# Altium schematic sheet sign_controller.SchDoc
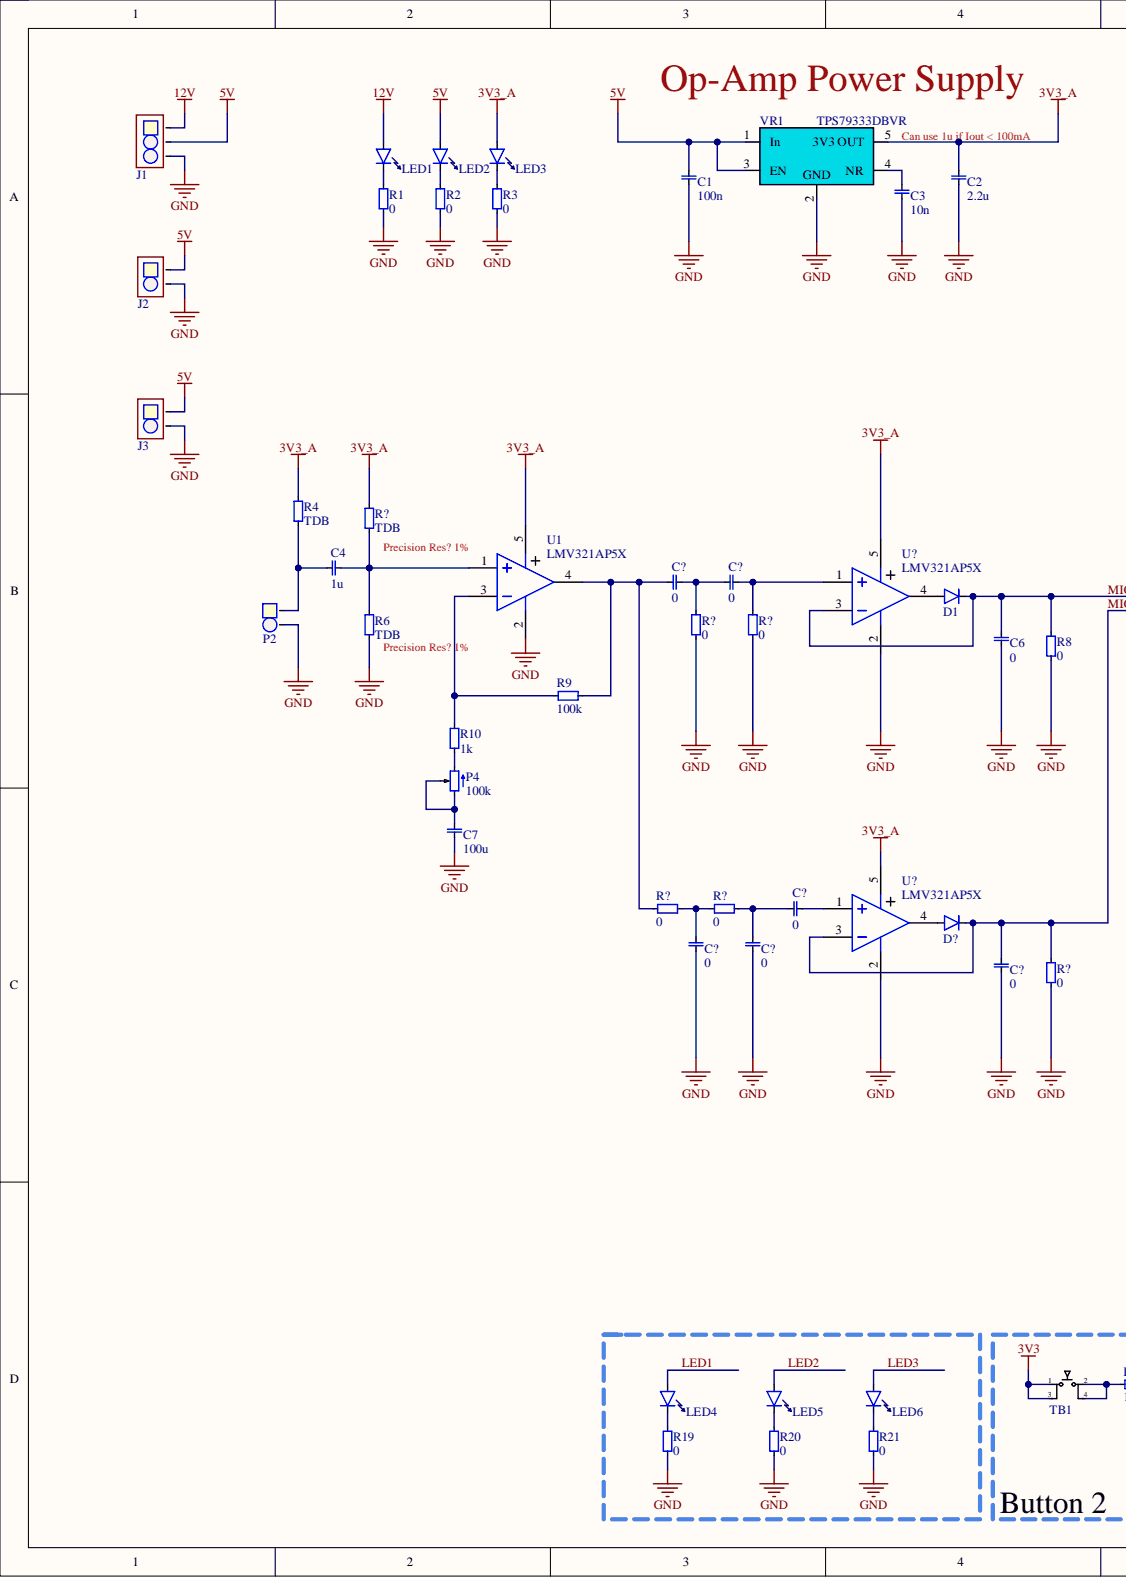
[diagram p0: sign_controller.SchDoc, left]
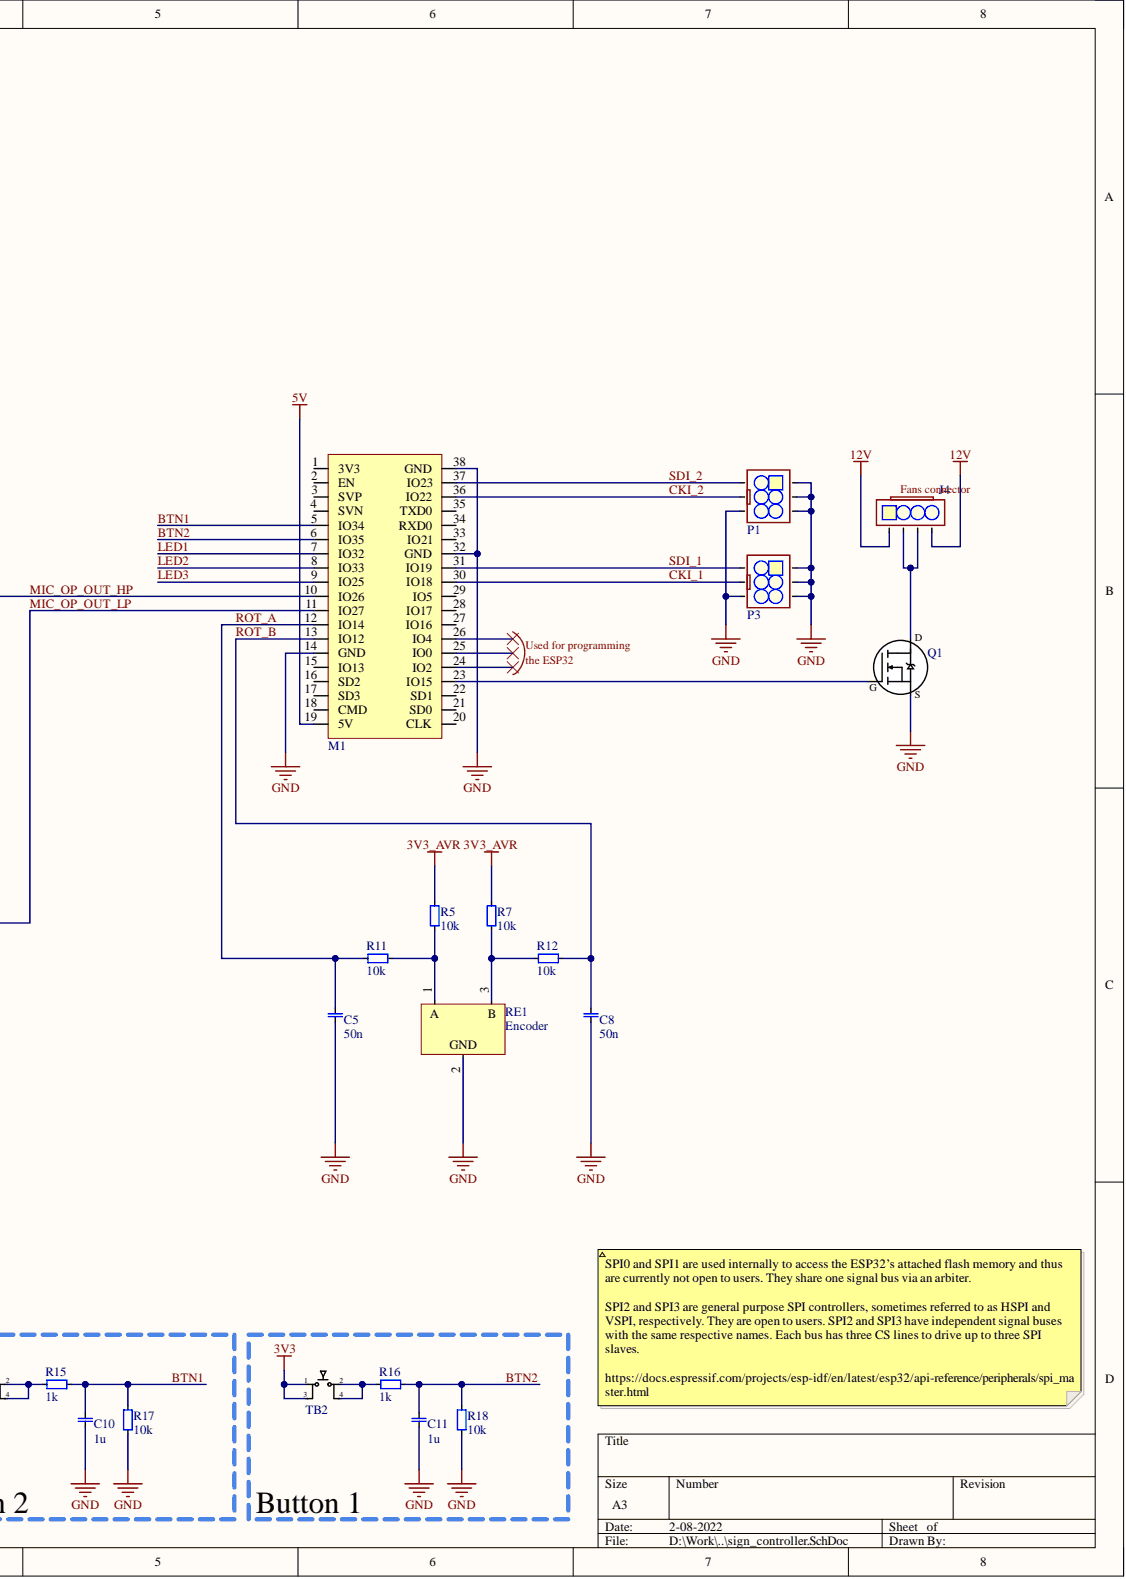
[diagram p1: sign_controller.SchDoc, right]

## Parts
C1  value 100n  [0603 cap]  (hidden comment: 0603, capacitor)
C2  value 2.2u  [1206, capasitor]  (hidden comment: 1206, capacitor)
C3  value 10n  [0603 cap]  (hidden comment: 0603, capacitor)
C4  value 1u  [1206, capasitor]  (hidden comment: 1206, capacitor)
C5  value 50n  [0603 cap]  (hidden comment: 0603, capacitor)
C6  value 0  [0603 cap]  (hidden comment: 0603, capacitor)
C7  value 100u  [1206, capasitor]  (hidden comment: 1206, capacitor)
C8  value 50n  [0603 cap]  (hidden comment: 0603, capacitor)
C10  value 1u  [0603 cap]  (hidden comment: 0603, capacitor)
C11  value 1u  [0603 cap]  (hidden comment: 0603, capacitor)
C?  value 0  [0603 cap]  (hidden comment: 0603, capacitor)
C?  value 0  [0603 cap]  (hidden comment: 0603, capacitor)
C?  value 0  [0603 cap]  (hidden comment: 0603, capacitor)
C?  value 0  [0603 cap]  (hidden comment: 0603, capacitor)
C?  value 0  [0603 cap]  (hidden comment: 0603, capacitor)
C?  value 0  [0603 cap]  (hidden comment: 0603, capacitor)
D1  TH, diode  [TH]  (value not drawn)
D?  TH, diode  [TH]  (value not drawn)
J1  3 Pole MSTBA Connector  [3POLE_MSTBA_ANGLE]  (value not drawn)
J2  2 Pole MSTBA Connector  [2POLE_MSTBA_ANGLE]  (value not drawn)
J3  2 Pole MSTBA Connector  [2POLE_MSTBA_ANGLE]  (value not drawn)
J4  1x4 Molex  [1x4 Bent Pin Header]  (value not drawn)
LED1  0805, LED  [0805_led]  (value not drawn)
LED2  0805, LED  [0805_led]  (value not drawn)
LED3  0805, LED  [0805_led]  (value not drawn)
LED4  0805, LED  [0805_led]  (value not drawn)
LED5  0805, LED  [0805_led]  (value not drawn)
LED6  0805, LED  [0805_led]  (value not drawn)
M1  ESP32-DEVKITC-32D-F  [ESP32_DEVKITC]  (value not drawn)
P1  2x3 Pin Header  [2x3 PH]  (value not drawn)
P2  1x2 Pin Header  [padtwo_L]  (value not drawn)
P3  2x3 Pin Header  [2x3 PH]  (value not drawn)
P4  value 100k  [POT_TH1]  (hidden comment: Pot)
Q1  IRLB8314  [TO-220]  (value not drawn)
R1  value 0  [0603]  (hidden comment: 0603, resistor)
R2  value 0  [0603]  (hidden comment: 0603, resistor)
R3  value 0  [0603]  (hidden comment: 0603, resistor)
R4  value TDB  [0603]  (hidden comment: 0603, resistor)
R5  value 10k  [0603]  (hidden comment: 0603, resistor)
R6  value TDB  [0603]  (hidden comment: 0603, resistor)
R7  value 10k  [0603]  (hidden comment: 0603, resistor)
R8  value 0  [0603]  (hidden comment: 0603, resistor)
R9  value 100k  [0603]  (hidden comment: 0603, resistor)
R10  value 1k  [0603]  (hidden comment: 0603, resistor)
R11  value 10k  [0603]  (hidden comment: 0603, resistor)
R12  value 10k  [0603]  (hidden comment: 0603, resistor)
R15  value 1k  [0402 res]  (hidden comment: 0603, resistor)
R16  value 1k  [0402 res]  (hidden comment: 0603, resistor)
R17  value 10k  [0402 res]  (hidden comment: 0603, resistor)
R18  value 10k  [0402 res]  (hidden comment: 0603, resistor)
R19  value 0  [0603]  (hidden comment: 0603, resistor)
R20  value 0  [0603]  (hidden comment: 0603, resistor)
R21  value 0  [0603]  (hidden comment: 0603, resistor)
R?  value TDB  [0603]  (hidden comment: 0603, resistor)
R?  value 0  [0603]  (hidden comment: 0603, resistor)
R?  value 0  [0603]  (hidden comment: 0603, resistor)
R?  value 0  [0603]  (hidden comment: 0603, resistor)
R?  value 0  [0603]  (hidden comment: 0603, resistor)
R?  value 0  [0603]  (hidden comment: 0603, resistor)
RE1  Encoder  [RotaryEncoder]
TB1  Tactile Button  [tacButton smd]  (value not drawn)
TB2  Tactile Button  [tacButton smd]  (value not drawn)
U1  LMV321AP5X  [SOT23-5]
U?  LMV321AP5X  [SOT23-5]
U?  LMV321AP5X  [SOT23-5]
VR1  TPS79333DBVR  [SOT23-5]

## Nets
3V3: TB1.1 TB1.3 TB2.1 TB2.3
3V3_A: C2.1 LED3.1 R4.0 R?.1 U1.5 U?.5 VR1.5(3V3_OUT)
3V3_AVR: R5.1 R7.1
5V: C1.1 J1.2 J2.1 J3.1 LED2.1 M1.19(5V) VR1.1(In) VR1.3(EN)
12V: J1.1 J4.1 J4.4 LED1.1
GND: C1.0 C2.0 C3.0 C5.0 C6.0 C7.0 C8.0 C10.0 C11.0 C?.0 C?.1 J1.3 J2.2 J3.2 M1.14(GND) M1.32(GND) M1.38(GND) P1.1 P1.2 P1.3 P1.4 P2.2 P3.1 P3.2 P3.3 P3.4 Q1.3 R1.1 R2.1 R3.1 R6.1 R8.1 R17.1 R18.0 R19.1 R20.1 R21.1 R?.1 RE1.2 U1.2 U?.2 VR1.2
BTN1: C10.1 M1.5(IO34) R15.1 R17.0
BTN2: C11.1 M1.6(IO35) R16.1 R18.1
CKI_1: M1.30(IO18) P3.5
CKI_2: M1.36(IO22) P1.5
LED1: LED4.1 M1.7(IO32)
LED2: LED5.1 M1.8(IO33)
LED3: LED6.1 M1.9(IO25)
MIC_OP_OUT_HP: C6.1 D1.2 M1.10(IO26) R8.0 U?.3
MIC_OP_OUT_LP: C?.1 D?.2 M1.11(IO27) R?.0 U?.3
ROT_A: C5.1 M1.12(IO14) R11.0
ROT_B: C8.1 M1.13(IO12) R12.0
SDI_1: M1.31(IO19) P3.6
SDI_2: M1.37(IO23) P1.6
NetC3_1: C3.1 VR1.4(NR)
NetC4_0: C4.0 R6.0 R?.0 U1.1
NetC4_1: C4.1 P2.1 R4.1
NetC7_1: C7.1 P4.1 P4.2
NetC?_0: C?.0 C?.1 R?.0 R?.1 U?.1
NetC?_1: C?.1 R9.1 R?.0 U1.4
NetD1_1: D1.1 U?.4
NetD?_1: D?.1 U?.4
NetJ4_2: J4.2 J4.3 Q1.2
NetLED1_0: LED1.0 R1.0
NetLED2_0: LED2.0 R2.0
NetLED3_0: LED3.0 R3.0
NetLED4_0: LED4.0 R19.0
NetLED5_0: LED5.0 R20.0
NetLED6_0: LED6.0 R21.0
NetM1_23: M1.23(IO15) Q1.1
NetP4_3: P4.3 R10.1
NetR5_0: R5.0 R11.1 RE1.1
NetR7_0: R7.0 R12.1 RE1.3
NetR9_0: R9.0 R10.0 U1.3
NetR15_0: R15.0 TB1.2 TB1.4
NetR16_0: R16.0 TB2.2 TB2.4

Not connected: M1 1(3V3) 2(EN) 3(SVP) 4(SVN) 15(IO13) 16(SD2) 17(SD3) 18(CMD) 20(CLK) 21(SD0) 22(SD1) 24(IO2) 25(IO0) 26(IO4) 27(IO16) 28(IO17) 29(IO5) 33(IO21) 34(RXD0) 35(TXD0)

## Library data of the parts
LED1-LED6 = 0805, LED: Value: 0805 LED; Component Kind: Standard; Library Name: sign_lib.IntLib
P1 P3 = 2x3 Ribbon connector: Value: 2x3 Pin Header, 2.54mm; Component Kind: Standard; Description: 2x3 Ribbon connector; Library Name: sign_lib.SchLib
P2 = 1x2 Pin Header: 2.54 mm, TH; Component Kind: Standard; Value: 1x2 Pin Header; Library Name: sign_lib.IntLib
RE1 = RotaryEncoder: Component Kind: Standard; Library Name: sign_lib.IntLib
TB1 TB2 = TacButton SMD: Tactile Switch Small Low; Component Kind: Standard; ELFA: 110-87-406; Value: Button SMD; Library Name: jclib.IntLib
U1 U? U? = LMV321AP5X: Operational Amplifier, Low Power, Rail to Rail Output, CMOS Op-Amp; Manufacturer: ON Semiconductor / Fairchild; Bandwidth: 1.4MHz; Common Mode Rejection Ratio: 50dB; Input Bias Current: 1nA; Input Offset Voltage (Vos): 7mV; Max Dual Supply Voltage: 2.75V; Max Supply Voltage: 5.5V; Min Dual Supply Voltage: 1.35V; Min Supply Voltage: 2.7V; Nominal Supply Current: 80uA; Number of Amplifiers: 1; Operating Supply Current: 100uA; Output Current: 34mA; Slew Rate: 1.5V/µs; Voltage Gain: 70dB; Case/Package: SC; Category: Amplifiers - Op Amps, Buffer, Instrumentation; Component Kind: Standard; ComponentLink1Description: https://datasheet.ciiva.com/25629/0900766b810c08e0-25629312.pdf?src-supplier=RSComponents; ComponentLink1URL: https://datasheet.ciiva.com/25629/0900766b810c08e0-25629312.pdf?src-supplier=RSComponents; ComponentLink2Description: https://datasheet.ciiva.com/17359/lmv321-17359885.pdf?src-supplier=Future+Electronics; ComponentLink2URL: https://datasheet.ciiva.com/17359/lmv321-17359885.pdf?src-supplier=Future+Electronics; ComponentLink3Description: https://datasheet.ciiva.com/26243/lmv358-26243564.pdf?src-supplier=Avnet+Europe; ComponentLink3URL: https://datasheet.ciiva.com/26243/lmv358-26243564.pdf?src-supplier=Avnet+Europe; ComponentLink4Description: https://datasheet.ciiva.com/1792/lmv321-1792987.pdf?src-supplier=Digi-Key; ComponentLink4URL: https://datasheet.ciiva.com/1792/lmv321-1792987.pdf?src-supplier=Digi-Key; ComponentLink5Description: https://datasheet.ciiva.com/1792/lmv321-1792988.pdf?src-supplier=Digi-Key; ComponentLink5URL: https://datasheet.ciiva.com/1792/lmv321-1792988.pdf?src-supplier=Digi-Key; ComponentLink6Description: https://datasheet.ciiva.com/1792/lmv321-1792986.pdf?src-supplier=Digi-Key; ComponentLink6URL: https://datasheet.ciiva.com/1792/lmv321-1792986.pdf?src-supplier=Digi-Key; Gain Bandwidth Product: 1.4MHz; Height: 1mm; Lead Free: Lead Free; Length: 2.2mm; Manufacturer Part Number: LMV321AP5X; Max Operating Temperature: 125°C; Min Operating Temperature: -40°C; Mount: Surface Mount; Number of Channels: 1; Number of Circuits: 1; Number of Elements: 1; Number of Pins: 5; Operating Supply Voltage: 5.5V; Output Current per Channel: 34mA; Package Quantity: 1; Packaging: Tape and Reel; Power Supply Rejection Ratio (PSRR): 50dB; Pricing 1: 1=0,51000, 10=0,43100, 50=0,43100, 100=0,29900, 500=0,25300, 1000=0,19600, 3000=0,17700, 9000=0,16700, 10000=0,16700 (USD); Radiation Hardening: No; REACH SVHC: No SVHC; RoHS: Yes; RoHS Compliant: Yes; Supplier 1: Mouser; Supplier Part Number 1: 512-LMV321AP5X; Unity Gain Bandwidth Product: 1.4MHz; Weight: 23g; Width: 1.35mm; Library Name: sign_lib.IntLib
VR1 = TPS79333DBVR: Low Dropout Regulators(LDO) Positive Fixed 180mV @ 200mA 5.5V 3.3V 200mA SOT-23-5 RoHS; Manufacturer: Texas Instruments; Accuracy: 2%; Dropout Voltage: 102mV; Input Bias Current: 170uA; Max Power Dissipation: 560mW; Min Current Limit: 285mA; Min Input Voltage: 2.7V; Number of Outputs: 1; Quiescent Current: 200uA; Case/Package: SOT-23; Category: Voltage Regulators - Linear; Component Kind: Standard; ComponentLink1Description: https://datasheet.ciiva.com/34302/1123416-34302001.pdf?src-supplier=Jameco; ComponentLink1URL: https://datasheet.ciiva.com/34302/1123416-34302001.pdf?src-supplier=Jameco; ComponentLink2Description: https://datasheet.ciiva.com/22734/1828169-22734577.pdf?src-supplier=Farnell; ComponentLink2URL: https://datasheet.ciiva.com/22734/1828169-22734577.pdf?src-supplier=Farnell; Current Rating: 200mA; Height: 1.15mm; Lead Free: Lead Free; Length: 2.9mm; Manufacturer Part Number: TPS79333DBVR; Max Input Voltage: 5.5V; Max Operating Temperature: 125°C; Max Output Current: 200mA; Max Output Voltage: 3.3V; Max Supply Voltage: 5.5V; Min Operating Temperature: -40°C; Min Output Voltage: 3.3V; Min Supply Voltage: 2.7V; Nominal Output Voltage: 3.3V; Number of Pins: 5; Number of Regulators: 1; Output Current: 200mA; Output Type: Fixed; Output Voltage: 3.3V; Output Voltage Accuracy: 2%; Package Quantity: 1; Packaging: Tape and Reel; Polarity: Positive; Power Dissipation: 560mW; Power Rating: 560mW; Pricing 1: 1=0,94000, 10=0,81900, 50=0,81900, 100=0,62800, 250=0,58300, 500=0,49700, 1000=0,39700, 3000=0,36000, 10000=0,36000 (USD); Radiation Hardening: No; REACH SVHC: No SVHC; RoHS: Yes; RoHS Compliant: Yes; Supplier 1: Mouser; Supplier Part Number 1: 595-TPS79333DBVR; Termination: SMD/SMT; Width: 1.6mm; Library Name: sign_lib.SchLib
C1 C3 C5 C6 C8 C10 C11 C? C? C? C? C? C? = 0603, capacitor: Component Kind: Standard
  C1 C3 C5 C6 C8 C? C? C? C? C? C?: Library Name: sign_lib.IntLib
  C10 C11: Library Name: jclib.SchLib
C2 C4 C7 = 1206, capacitor: Component Kind: Standard; Library Name: sign_lib.IntLib
D1 D? = TH, diode: Component Kind: Standard; Library Name: sign_lib.IntLib
J1 = 3 Pole MSTBA Connector: Component Kind: Standard; Library Name: sign_lib.IntLib
J2 J3 = 2 Pole MSTBA Connector: Component Kind: Standard; Library Name: sign_lib.IntLib
J4 = 1x4 Molex: Component Kind: Standard; Library Name: sign_lib.IntLib
M1 = ESP32-DEVKITC-32D-F: Component Kind: Standard; Library Name: sign_lib.IntLib
P4 = Pot: Component Kind: Standard; Library Name: sign_lib.IntLib
Q1 = IRLB8314: Component Kind: Standard; Library Name: sign_lib.IntLib
R1-R12 R15-R21 R? R? R? R? R? R? = 0603, resistor: Component Kind: Standard
  R1-R12 R19-R21 R? R? R? R? R? R?: Library Name: sign_lib.IntLib
  R15-R18: Library Name: jclib.IntLib
